# Altium schematic sheet GyroAccl.SchDoc
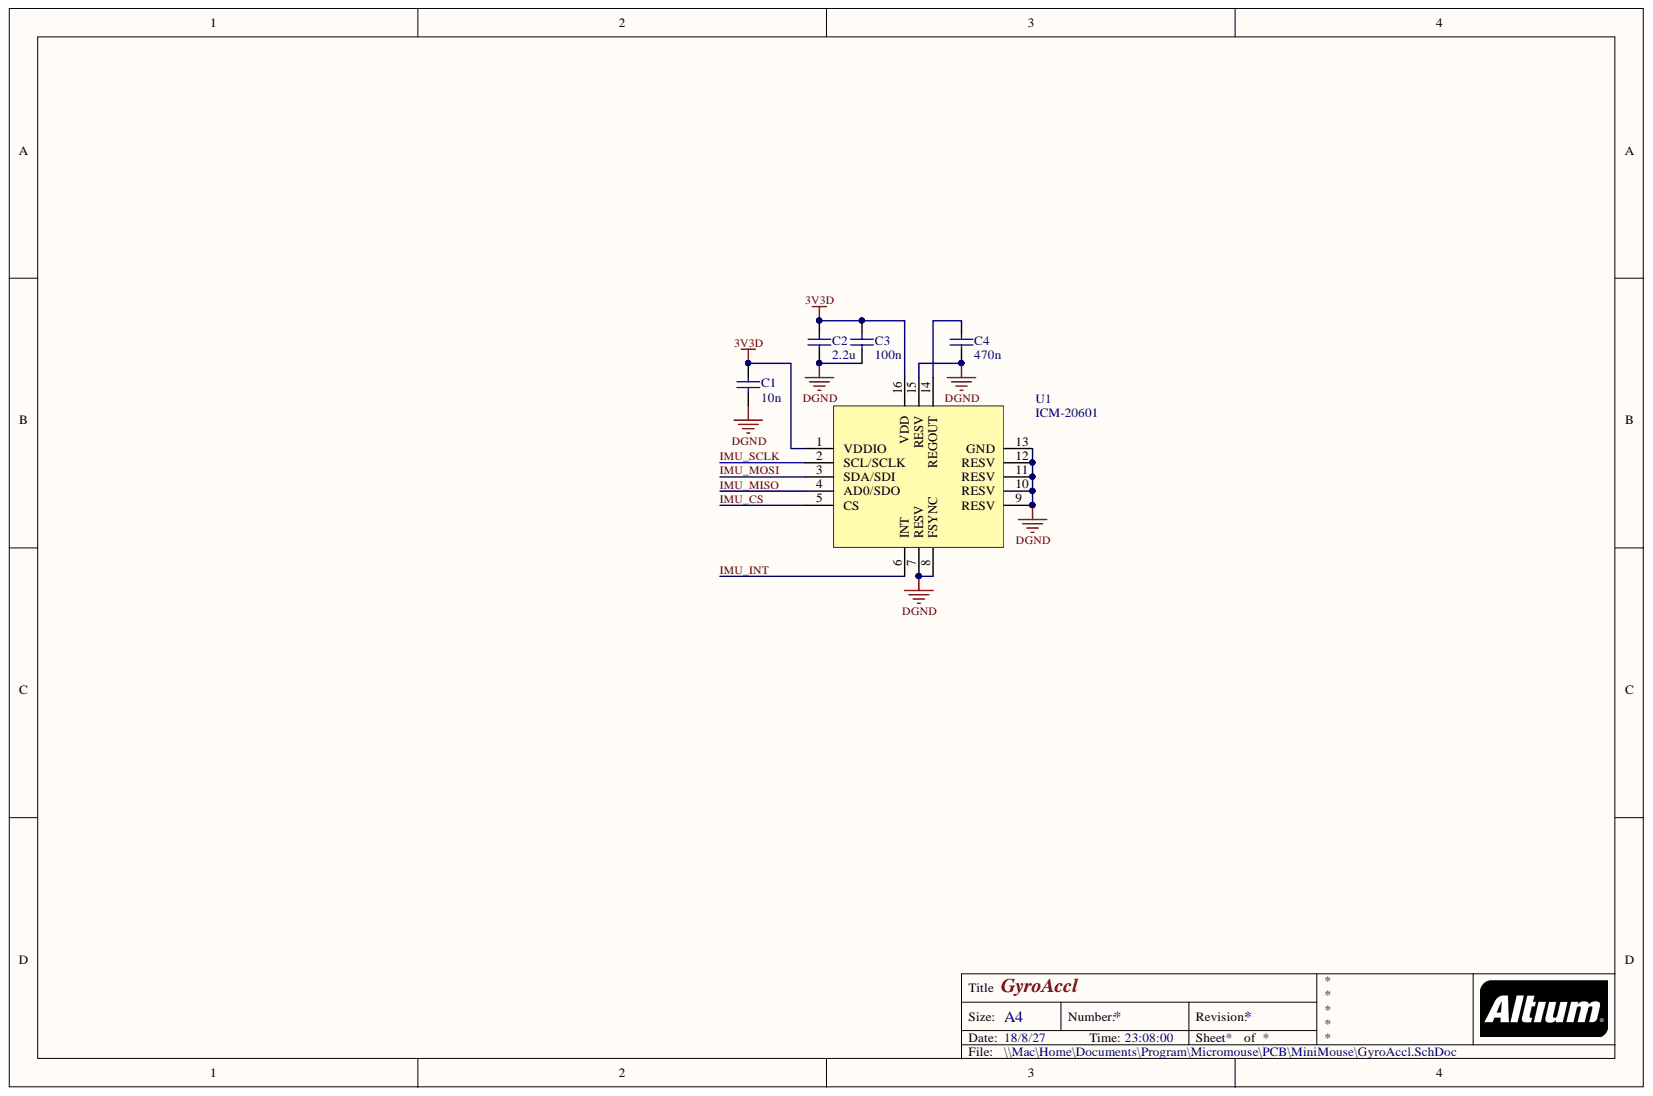
[diagram p0: GyroAccl.SchDoc]

## Parts
C1  10n  [CAPC1005[0402]]
C2  2.2u  [CAPC1005[0402]]
C3  100n  [CAPC1005[0402]]
C4  470n  [CAPC1005[0402]]
U1  ICM-20601  [ICM-20601]

## Nets
3V3D: C1.1 C2.1 C3.1 U1.1(VDDIO) U1.16(VDD)
DGND: C1.2 C2.2 C3.2 C4.2 U1.7(RESV) U1.8(FSYNC) U1.9(RESV) U1.10(RESV) U1.11(RESV) U1.12(RESV) U1.13(GND) U1.15(RESV)
IMU_CS: U1.5(CS)
IMU_INT: U1.6(INT)
IMU_MISO: U1.4(AD0/SDO)
IMU_MOSI: U1.3(SDA/SDI)
IMU_SCLK: U1.2(SCL/SCLK)
NetC4_1: C4.1 U1.14(REGOUT)

## Library data of the parts
C1-C4 = Cap: Capacitor; Component Kind: Standard; LatestRevisionDate: 17-Jul-2002; LatestRevisionNote: Re-released for DXP Platform.; Library Name: Miscellaneous Devices.IntLib; PackageDescription: Radial Cap, Thru-Hole; 2 Leads; 0.3 in Pin Spacing; PackageReference: RAD-0.3; Published: 8-Jun-2000; Signal Integrity: Cap; Simulation: CAP; Publisher: Altium Limited
  C1: Value: 10n
  C2: Value: 2.2u
  C3: Value: 100n
  C4: Value: 470n
U1 = ICM-20601: Component Kind: Standard; Library Name: LIQI.SchLib
